# Altium schematic sheet ATMEGA2560.SchDoc(ATMEGA2560)
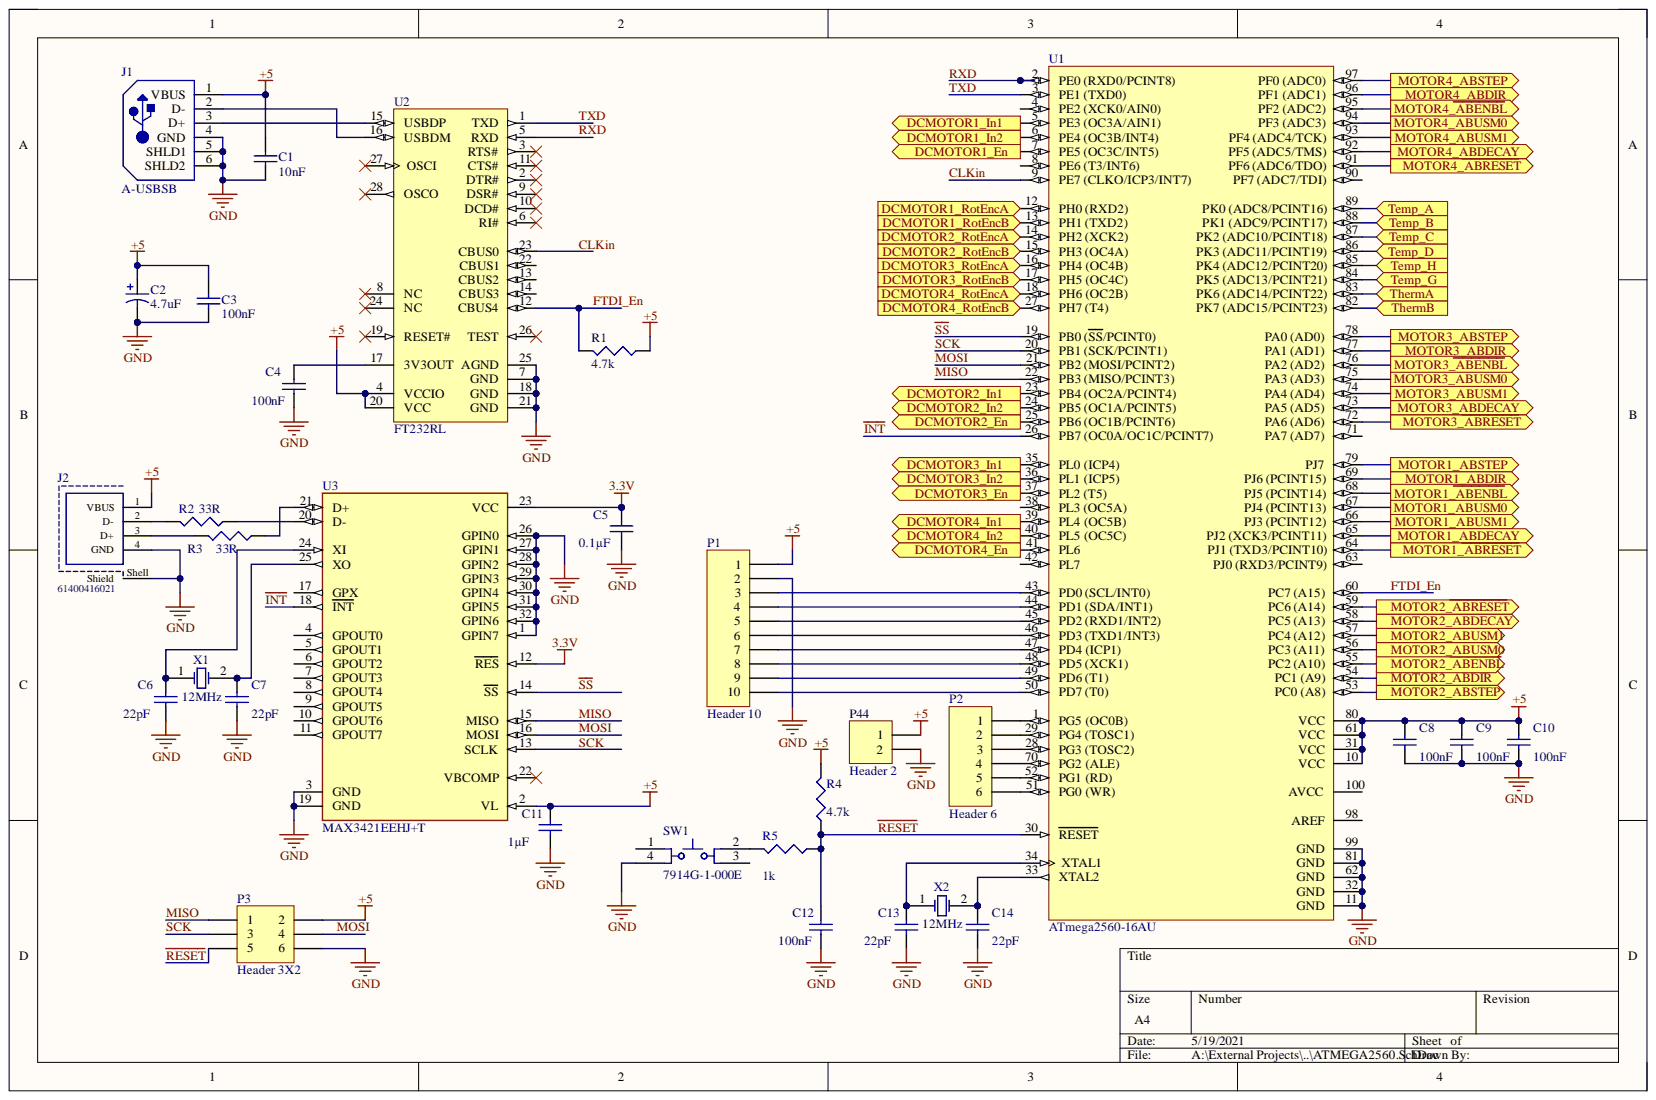
[diagram p0: ATMEGA2560.SchDoc]

## Parts
C1  value 10nF  [C1206]  (hidden comment: Cap Semi)
C2  value 4.7uF  [RB7.6-15]  (hidden comment: Cap Pol1)
C3  value 100nF  [C1206]  (hidden comment: Cap Semi)
C4  value 100nF  [C1206]  (hidden comment: Cap Semi)
C5  value 0.1µF  [C1206]  (hidden comment: Cap Semi)
C6  value 22pF  [C1206]  (hidden comment: Cap Semi)
C7  value 22pF  [C1206]  (hidden comment: Cap Semi)
C8  value 100nF  [C1206]  (hidden comment: Cap Semi)
C9  value 100nF  [C1206]  (hidden comment: Cap Semi)
C10  value 100nF  [C1206]  (hidden comment: Cap Semi)
C11  value 1µF  [C1206]  (hidden comment: Cap Semi)
C12  value 100nF  [C1206]  (hidden comment: Cap Semi)
C13  value 22pF  [C1206]  (hidden comment: Cap Semi)
C14  value 22pF  [C1206]  (hidden comment: Cap Semi)
J1  A-USBSB  [ASSM-A-USBSB-4_V]
J2  61400416021  [61400416021]
P1  Header 10  [HDR1X10]
P2  Header 6  [HDR1X6]
P3  Header 3X2  [HDR2X3]
P44  Header 2  [HDR1X2]
R1  value 4.7k  [J1-0603]  (hidden comment: Res3)
R2  value 33R  [J1-0603]  (hidden comment: Res3)
R3  value 33R  [J1-0603]  (hidden comment: Res3)
R4  value 4.7k  [J1-0603]  (hidden comment: Res3)
R5  value 1k  [J1-0603]  (hidden comment: Res3)
SW1  7914G-1-000E  [BOUR-7914G-1-000E_V]
U1  ATmega2560-16AU  [100A_M]
U2  FT232RL  [FTDI-SSOP-28_M]
U3  MAX3421EEHJ+T  [FP-H32-1-MFG]
X1  12MHz  [ABRA-ABLS-14.7456MHZ-B2-T_V]
X2  12MHz  [ABRA-ABLS-14.7456MHZ-B2-T_V]

## Ports
DCMOTOR1_En, DCMOTOR1_In1, DCMOTOR1_In2, DCMOTOR1_RotEncA, DCMOTOR1_RotEncB, DCMOTOR2_En, DCMOTOR2_In1, DCMOTOR2_In2, DCMOTOR2_RotEncA, DCMOTOR2_RotEncB, DCMOTOR3_En, DCMOTOR3_In1, DCMOTOR3_In2, DCMOTOR3_RotEncA, DCMOTOR3_RotEncB, DCMOTOR4_En, DCMOTOR4_In1, DCMOTOR4_In2, DCMOTOR4_RotEncA, DCMOTOR4_RotEncB, MOTOR1_ABDECAY, MOTOR1_ABDIR, MOTOR1_ABSTEP, MOTOR1_ABUSM0, MOTOR1_ABUSM1, MOTOR1_~{ABENBL}, MOTOR1_~{ABRESET}, MOTOR2_ABDECAY, MOTOR2_ABDIR, MOTOR2_ABSTEP, MOTOR2_ABUSM0, MOTOR2_ABUSM1, MOTOR2_~{ABENBL}, MOTOR2_~{ABRESET}, MOTOR3_ABDECAY, MOTOR3_ABDIR, MOTOR3_ABSTEP, MOTOR3_ABUSM0, MOTOR3_ABUSM1, MOTOR3_~{ABENBL}, MOTOR3_~{ABRESET}, MOTOR4_ABDECAY, MOTOR4_ABDIR, MOTOR4_ABSTEP, MOTOR4_ABUSM0, MOTOR4_ABUSM1, MOTOR4_~{ABENBL}, MOTOR4_~{ABRESET}, Temp_A, Temp_B, Temp_C, Temp_D, Temp_G, Temp_H, ThermA, ThermB

## Nets
3.3V: C5.2 U3.12(RES) U3.23(VCC)
+5: C1.2 C2.1 C3.2 C8.2 C9.2 C10.2 C11.2 J1.1(VBUS) J2.1(VBUS) P1.1 P3.2 P44.1 R1.1 R4.2 U1.10(VCC) U1.31(VCC) U1.61(VCC) U1.80(VCC) U2.4(VCCIO) U2.20(VCC) U3.2(VL)
GND: C1.1 C2.2 C3.1 C4.1 C5.1 C6.1 C7.1 C8.1 C9.1 C10.1 C11.1 C12.1 C13.1 C14.1 J1.4(GND) J1.5(SHLD1) J1.6(SHLD2) J2.4(GND) J2.Shell(Shield) P1.2 P3.6 P44.2 SW1.4 U1.11(GND) U1.32(GND) U1.62(GND) U1.81(GND) U1.99(GND) U2.7(GND) U2.18(GND) U2.21(GND) U2.25(AGND) U3.1(GPIN7) U3.3(GND) U3.19(GND) U3.26(GPIN0) U3.27(GPIN1) U3.28(GPIN2) U3.29(GPIN3) U3.30(GPIN4) U3.31(GPIN5) U3.32(GPIN6)
~{INT}: U1.26(PB7_(OC0A/OC1C/PCINT7)) U3.18(INT)
~{RESET}: C12.2 P3.5 R4.1 R5.1 U1.30(RESET)
~{SS}: U1.19(PB0_(SS/PCINT0)) U3.14(SS)
CLKin: U1.9(PE7_(CLKO/ICP3/INT7)) U2.23(CBUS0)
DCMOTOR1_En: U1.7(PE5_(OC3C/INT5)) ; port DCMOTOR1_En
DCMOTOR1_In1: U1.5(PE3_(OC3A/AIN1)) ; port DCMOTOR1_In1
DCMOTOR1_In2: U1.6(PE4_(OC3B/INT4)) ; port DCMOTOR1_In2
DCMOTOR1_RotEncA: U1.12(PH0_(RXD2)) ; port DCMOTOR1_RotEncA
DCMOTOR1_RotEncB: U1.13(PH1_(TXD2)) ; port DCMOTOR1_RotEncB
DCMOTOR2_En: U1.25(PB6_(OC1B/PCINT6)) ; port DCMOTOR2_En
DCMOTOR2_In1: U1.23(PB4_(OC2A/PCINT4)) ; port DCMOTOR2_In1
DCMOTOR2_In2: U1.24(PB5_(OC1A/PCINT5)) ; port DCMOTOR2_In2
DCMOTOR2_RotEncA: U1.14(PH2_(XCK2)) ; port DCMOTOR2_RotEncA
DCMOTOR2_RotEncB: U1.15(PH3_(OC4A)) ; port DCMOTOR2_RotEncB
DCMOTOR3_En: U1.37(PL2_(T5)) ; port DCMOTOR3_En
DCMOTOR3_In1: U1.35(PL0_(ICP4)) ; port DCMOTOR3_In1
DCMOTOR3_In2: U1.36(PL1_(ICP5)) ; port DCMOTOR3_In2
DCMOTOR3_RotEncA: U1.16(PH4_(OC4B)) ; port DCMOTOR3_RotEncA
DCMOTOR3_RotEncB: U1.17(PH5_(OC4C)) ; port DCMOTOR3_RotEncB
DCMOTOR4_En: U1.41(PL6) ; port DCMOTOR4_En
DCMOTOR4_In1: U1.39(PL4_(OC5B)) ; port DCMOTOR4_In1
DCMOTOR4_In2: U1.40(PL5_(OC5C)) ; port DCMOTOR4_In2
DCMOTOR4_RotEncA: U1.18(PH6_(OC2B)) ; port DCMOTOR4_RotEncA
DCMOTOR4_RotEncB: U1.27(PH7_(T4)) ; port DCMOTOR4_RotEncB
FTDI_En: R1.2 U1.60(PC7_(A15)) U2.12(CBUS4)
MISO: P3.1 U1.22(PB3_(MISO/PCINT3)) U3.15(MISO)
MOSI: P3.4 U1.21(PB2_(MOSI/PCINT2)) U3.16(MOSI)
MOTOR1_~{ABENBL}: U1.68(PJ5_(PCINT14)) ; port MOTOR1_~{ABENBL}
MOTOR1_~{ABRESET}: U1.64(PJ1_(TXD3/PCINT10)) ; port MOTOR1_~{ABRESET}
MOTOR1_ABDECAY: U1.65(PJ2_(XCK3/PCINT11)) ; port MOTOR1_ABDECAY
MOTOR1_ABDIR: U1.69(PJ6_(PCINT15)) ; port MOTOR1_ABDIR
MOTOR1_ABSTEP: U1.79(PJ7) ; port MOTOR1_ABSTEP
MOTOR1_ABUSM0: U1.67(PJ4_(PCINT13)) ; port MOTOR1_ABUSM0
MOTOR1_ABUSM1: U1.66(PJ3_(PCINT12)) ; port MOTOR1_ABUSM1
MOTOR2_~{ABENBL}: U1.55(PC2_(A10)) ; port MOTOR2_~{ABENBL}
MOTOR2_~{ABRESET}: U1.59(PC6_(A14)) ; port MOTOR2_~{ABRESET}
MOTOR2_ABDECAY: U1.58(PC5_(A13)) ; port MOTOR2_ABDECAY
MOTOR2_ABDIR: U1.54(PC1_(A9)) ; port MOTOR2_ABDIR
MOTOR2_ABSTEP: U1.53(PC0_(A8)) ; port MOTOR2_ABSTEP
MOTOR2_ABUSM0: U1.56(PC3_(A11)) ; port MOTOR2_ABUSM0
MOTOR2_ABUSM1: U1.57(PC4_(A12)) ; port MOTOR2_ABUSM1
MOTOR3_~{ABENBL}: U1.76(PA2_(AD2)) ; port MOTOR3_~{ABENBL}
MOTOR3_~{ABRESET}: U1.72(PA6_(AD6)) ; port MOTOR3_~{ABRESET}
MOTOR3_ABDECAY: U1.73(PA5_(AD5)) ; port MOTOR3_ABDECAY
MOTOR3_ABDIR: U1.77(PA1_(AD1)) ; port MOTOR3_ABDIR
MOTOR3_ABSTEP: U1.78(PA0_(AD0)) ; port MOTOR3_ABSTEP
MOTOR3_ABUSM0: U1.75(PA3_(AD3)) ; port MOTOR3_ABUSM0
MOTOR3_ABUSM1: U1.74(PA4_(AD4)) ; port MOTOR3_ABUSM1
MOTOR4_~{ABENBL}: U1.95(PF2_(ADC2)) ; port MOTOR4_~{ABENBL}
MOTOR4_~{ABRESET}: U1.91(PF6_(ADC6/TDO)) ; port MOTOR4_~{ABRESET}
MOTOR4_ABDECAY: U1.92(PF5_(ADC5/TMS)) ; port MOTOR4_ABDECAY
MOTOR4_ABDIR: U1.96(PF1_(ADC1)) ; port MOTOR4_ABDIR
MOTOR4_ABSTEP: U1.97(PF0_(ADC0)) ; port MOTOR4_ABSTEP
MOTOR4_ABUSM0: U1.94(PF3_(ADC3)) ; port MOTOR4_ABUSM0
MOTOR4_ABUSM1: U1.93(PF4_(ADC4/TCK)) ; port MOTOR4_ABUSM1
RXD: U1.2(PE0_(RXD0/PCINT8)) U2.5(RXD)
SCK: P3.3 U1.20(PB1_(SCK/PCINT1)) U3.13(SCLK)
Temp_A: U1.89(PK0_(ADC8/PCINT16)) ; port Temp_A
Temp_B: U1.88(PK1_(ADC9/PCINT17)) ; port Temp_B
Temp_C: U1.87(PK2_(ADC10/PCINT18)) ; port Temp_C
Temp_D: U1.86(PK3_(ADC11/PCINT19)) ; port Temp_D
Temp_G: U1.84(PK5_(ADC13/PCINT21)) ; port Temp_G
Temp_H: U1.85(PK4_(ADC12/PCINT20)) ; port Temp_H
ThermA: U1.83(PK6_(ADC14/PCINT22)) ; port ThermA
ThermB: U1.82(PK7_(ADC15/PCINT23)) ; port ThermB
TXD: U1.3(PE1_(TXD0)) U2.1(TXD)
NetC4_2: C4.2 U2.17(3V3OUT)
NetC6_2: C6.2 U3.24(XI) X1.1
NetC7_2: C7.2 U3.25(XO) X1.2
NetC13_2: C13.2 U1.34(XTAL1) X2.1
NetC14_2: C14.2 U1.33(XTAL2) X2.2
NetJ1_2: J1.2(D-) U2.16(USBDM)
NetJ1_3: J1.3(D+) U2.15(USBDP)
NetJ2_2: J2.2(D-) R2.2
NetJ2_3: J2.3(D+) R3.2
NetP1_3: P1.3 U1.43(PD0_(SCL/INT0))
NetP1_4: P1.4 U1.44(PD1_(SDA/INT1))
NetP1_5: P1.5 U1.45(PD2_(RXD1/INT2))
NetP1_6: P1.6 U1.46(PD3_(TXD1/INT3))
NetP1_7: P1.7 U1.47(PD4_(ICP1))
NetP1_8: P1.8 U1.48(PD5_(XCK1))
NetP1_9: P1.9 U1.49(PD6_(T1))
NetP1_10: P1.10 U1.50(PD7_(T0))
NetP2_1: P2.1 U1.1(PG5_(OC0B))
NetP2_2: P2.2 U1.29(PG4_(TOSC1))
NetP2_3: P2.3 U1.28(PG3_(TOSC2))
NetP2_4: P2.4 U1.70(PG2_(ALE))
NetP2_5: P2.5 U1.52(PG1_(RD))
NetP2_6: P2.6 U1.51(PG0_(WR))
NetR2_1: R2.1 U3.20(D-)
NetR3_1: R3.1 U3.21(D+)
NetR5_2: R5.2 SW1.2

Not connected: SW1 1 3; U1 4(PE2_(XCK0/AIN0)) 8(PE6_(T3/INT6)) 38(PL3_(OC5A)) 42(PL7) 63(PJ0_(RXD3/PCINT9)) 71(PA7_(AD7)) 90(PF7_(ADC7/TDI)) 98(AREF) 100(AVCC); U2 2(DTR#) 3(RTS#) 6(RI#) 8(NC) 9(DSR#) 10(DCD#) 11(CTS#) 13(CBUS2) 14(CBUS3) 19(RESET#) 22(CBUS1) 24(NC) 26(TEST) 27(OSCI) 28(OSCO); U3 4(GPOUT0) 5(GPOUT1) 6(GPOUT2) 7(GPOUT3) 8(GPOUT4) 9(GPOUT5) 10(GPOUT6) 11(GPOUT7) 17(GPX) 22(VBCOMP)

## Library data of the parts
C1 C3-C14 = Cap Semi: Capacitor (Semiconductor SIM Model); Component Kind: Standard; LatestRevisionDate: 29-May-2009; LatestRevisionNote: IPC-7351 Footprint Added.; Library Name: Miscellaneous Devices.IntLib; PackageDescription: Chip Capacitor; PackageReference: C1206; Published: 8-Jun-2000; Signal Integrity: Cap Semi; Simulation: CAP; Publisher: Altium Limited
C2 = Cap Pol1: Polarized Capacitor (Radial); Component Kind: Standard; LatestRevisionDate: 17-Jul-2002; LatestRevisionNote: Re-released for DXP Platform.; Library Name: Miscellaneous Devices.IntLib; PackageDescription: Polarized Capacitor (Radial); 2 Leads; PackageReference: RB7.6-15; Published: 8-Jun-2000; Signal Integrity: Cap Pol; Simulation: CAP; Publisher: Altium Limited
J1 = CMP-2000-05874-1: Connector Receptacle, USB Type B, Right Angle, -20 to 85 degC, 4-Pin THD, RoHS, Tray; Manufacturer: ASSMANN WSW; CAD Drawing: 3DCADModel; Gender: Female; Number of Ports: 1; Body Orientation: RightAngle; Component Kind: Standard; Datasheet URL: https://datasheet.ciiva.com/11731/0900766b80da421f-11731321.pdf; Datasheet Version: 12/2009; Library Name: Altium Content Vault; Manufacturer URL: http://www.assmann.us/; Mounting Technology: ThroughHole; Mounting Type: ThroughHole; Package Description: 4-Pin Through Hole Device, Body 16.5 x 12.04 mm; Package Reference: USBSB; Termination Method: Solder
J2 = CMP-1502-03227-1: USB 2.0 Type A Receptacle WR-COM, Horizontal, THT; Manufacturer: Wurth Elektronik; Contact Resistance: 20mOhm; Rated Current: 1.5A; Working Voltage: 30VAC; Component Kind: Standard; Connector Type: USB2.0TypeA; Datasheet URL: https://katalog.we-online.de/em/datasheet/61400416021.pdf; Gender: Receptacle; Library Name: Altium Content Vault; Manufacturer URL: http://www.we-online.de; Mounting Technology: THT; Operating Temperature: -40to105degC; Orientation: Horizontal; Package Reference: 61400416021; Packaging: Tray; Part Number: 61400416021; Publisher: WurthElektronik
P1 = Header 10: Header, 10-Pin; Component Kind: Standard; LatestRevisionDate: 17-Jul-2002; LatestRevisionNote: Re-released for DXP Platform.; Library Name: Miscellaneous Connectors.IntLib; Signal Integrity: Connector; Publisher: Altium Limited
P2 = Header 6: Header, 6-Pin; Component Kind: Standard; LatestRevisionDate: 17-Jul-2002; LatestRevisionNote: Re-released for DXP Platform.; Library Name: Miscellaneous Connectors.IntLib; Signal Integrity: Connector; Publisher: Altium Limited
P3 = Header 3X2: Header, 3-Pin, Dual row; Component Kind: Standard; LatestRevisionDate: 17-Jul-2002; LatestRevisionNote: Re-released for DXP Platform.; Library Name: Miscellaneous Connectors.IntLib; Signal Integrity: Connector; Publisher: Altium Limited
P44 = Header 2: Header, 2-Pin; Component Kind: Standard; LatestRevisionDate: 17-Jul-2002; LatestRevisionNote: Re-released for DXP Platform.; Library Name: Miscellaneous Connectors.IntLib; Signal Integrity: Connector; Publisher: Altium Limited
R1-R5 = Res3: Resistor; Component Kind: Standard; LatestRevisionDate: 29-May-2009; LatestRevisionNote: IPC-7351 Footprint Added.; Library Name: Miscellaneous Devices.IntLib; PackageDescription: Chip Resistor; PackageReference: J1-0603; Published: 8-Jun-2000; Signal Integrity: Res; Simulation: RESISTOR; Publisher: Altium Limited
SW1 = CMP-2000-05692-1: SPST Gull Wing Sealed Key Switch, 100 mA, 16 V, -55 to 125 degC, 4-Pin SMD, RoHS, Tape and Reel; Manufacturer: Bourns; Actuator Length: 1.65mm; Actuator Style: RoundButton; Base Material: Thermoplastic; Body Orientation: Straight; Contact Rating @ Voltage: 0.1A; COO: TW; Dielectric Strength: 250VAC; Ground Terminal: No; Illumination Type: N/R; Maximum AC Voltage Rating: 16V; Maximum Contact Resistance: 100mOhm; Mechanical Life: 100000Cycles; Operating Force: 2.94N; Switch Configuration: N.O.; Actuator Color: N/A; Component Kind: Standard; Contact Material: Gold; Contact Plating: N/A; Datasheet URL: http://www.bourns.com/docs/Product-Datasheets/7914.pdf; Datasheet Version: 08/2016; Dimension: 5x6.2x4.19mm; ECCN: EAR99; Electrical Life: N/A; Family: 7914; Housing Material: N/A; HTS: 8536509065; Library Name: Altium Content Vault; Manufacturer URL: http://www.bourns.com/; Maximum DC Voltage Rating: 16V; Minimum Insulation Resistance: 100MOhm; Mounting: SurfaceMount,GullWing; Mounting Technology: SurfaceMount; Operating Temperature: -55to125C; Package Description: 4-Pin Surface Mount Device, Body 5 x 5 mm, Height 4.49 mm; Package Reference: 7914G-1-000E; Packaging: EmbossedTapeandReel; Part No: 7914G-1-000E; Pole Throw Configuration: SPST; Product Weight: N/A; RoHS: Yes; Seal Description: N/A; Seal Location: N/A; Supplier: Bourns; Type: KeySwitch
U1 = CMP-0095-00210-2: 8-bit AVR Microcontroller, 4.5-5.5V, 16MHz, 256KB Flash, 4KB EEPROM, 8KB SRAM, 86 GPIO pins, 100-pin TQFP, Industrial Grade (-40°C to 85°C), Pb-Free; Code_JEDEC: MS-026-AED; Component Kind: Standard; ComponentLink1Description: Manufacturer URL; ComponentLink1URL: http://www.atmel.com/; ComponentLink2Description: ATmega640/1280/1281/2560/2561 Datasheet; ComponentLink2URL: http://www.atmel.com/dyn/resources/prod_documents/doc2549.pdf; ComponentLink3Description: ATmega640/1280/1281/2560/2561 Summary; ComponentLink3URL: http://www.atmel.com/dyn/resources/prod_documents/2549S.pdf; DatasheetVersion: revN, May-2011; Library Name: Altium Content Vault; PackageDescription: 100-Pin Thin Profile Plastic Quad Flat Pack (TQFP), 14 x 14 x 1.0 mm Body, 0.5 mm Pitch; PackageReference: 100A; PackageVersion: revD, Oct-2010; RevisionNote: DatasheetVersion & PackageVersion updated. URLs added.
U2 = CMP-2000-05029-1: IC USB FS SERIAL UART 28-SSOP; Manufacturer: FTDI; Maximum Data Rate: 3MBd; Number of Channels per Chip: 1; Receive FIFO: 256B; Transmit FIFO: 128B; Component Kind: Standard; Datasheet URL: http://datasheet.octopart.com/FT232RL-FTDI-datasheet-120501.pdf; Datasheet Version: Rev. 1.04, 02/2006; Dimensions: 10.2x5.3x1.75mm; Height: 1.75mm; Length: 10.2mm; Library Name: Altium Content Vault; Manufacturer URL: http://www.ftdichip.com/; Maximum Operating Temperature: +85degC; Minimum Operating Temperature: -40degC; Mounting Type: SurfaceMount; Package Type: SSOP; Pin Count: 28; Width: 5.3mm
U3 = CMP-05681-000001-1: IC USB PERIPH/HOST CNTRL 32TQFP; Manufacturer: Maxim Integrated; Data Rate: 12Mbits/s; Interface: SPI,USB; Max Junction Temp: +150°C; Max Supply Voltage: 3.6V; Min Supply Voltage: 3V; Nominal Supply Current: 45mA; Number Of Ports: 1; Standoff Height: 0.05mm; Usb Standard: USB 2.0; Automotive: No; Category: IC; Component Kind: Standard; ComponentLink2Description: Datasheet; ComponentLink2URL: https://datasheets.maximintegrated.com/en/ds/MAX3421E.pdf; Device Class L1: Integrated Circuits (ICs); Device Class L2: Interface ICs; Device Class L3: USB Interface ICs; Digikey Description: IC USB PERIPH/HOST CNTRL 32TQFP; Footprint Url: https://pdfserv.maximintegrated.com/land_patterns/90-0149.PDF; Height: 1.2mm; Ipc Land Pattern Name: QFP50P700X700X120-32; Lead Free: Yes; Library Name: Altium Content Vault; Manufacturer Part Number: MAX3421EEHJ+T; Mouser Description: USB Interface IC USB Peripheral Controller w/SPI; Octopart: https://octopart.com/max3421eehj%2Bt-maxim+integrated-39622526; Package: H32-1; RoHS: TRUE; Temperature: -40°C to +85°C; Temperature Range High: +85°C; Temperature Range Low: -40°C
X1 X2 = CMP-2000-05726-1: Low Profile Crystal, 8.0 MHz, +/- 30 ppm, -20 to 70 degC, 2-Pin SMD, RoHS, Tape and Reel; Brand: ABRACON; Case Height: 4.2mm; Case Length: 11.4mm; Case Width: 4.7mm; ESR: 80Ohms; Frequency: 8MHz; Frequency Stability: 50PPM; Load Capacitance: 18pF; Tolerance: 30PPM; Component Kind: Standard; Datasheet URL: http://www.abracon.com/Resonators/abls.pdf; Datasheet Version: 04/2011; Dimensions: 4.7mmWx11.4mmLx4.2mmH; Factory Pack Quantity: 1000; Library Name: Altium Content Vault; Manufacturer: ABRACON; Manufacturer URL: http://www.abracon.com/; Mounting Style: SMD/SMT; Mounting Technology: SurfaceMount; Operating Temperature Range: -20Cto+70C; Package / Case: HC-49/US; Package Description: 2-Pin Surface Mount Device, Body 11.4 x 4.7 mm; Package Reference: ABLS-14.7456MHZ-B2-T; Package URL: http://www.abracon.com/Support/PackageDrawing/Resonators/ABLS-2D.pdf; Packaging: Reel; Product Category: Crystals; RoHS: Details; Series: ABLS; Termination Style: SMD/SMT; Type: LowProfileMicroprocessorCrystal
